# Altium schematic sheet 主控转接板-V2.0.SchDoc(主控转接板-V2.0)
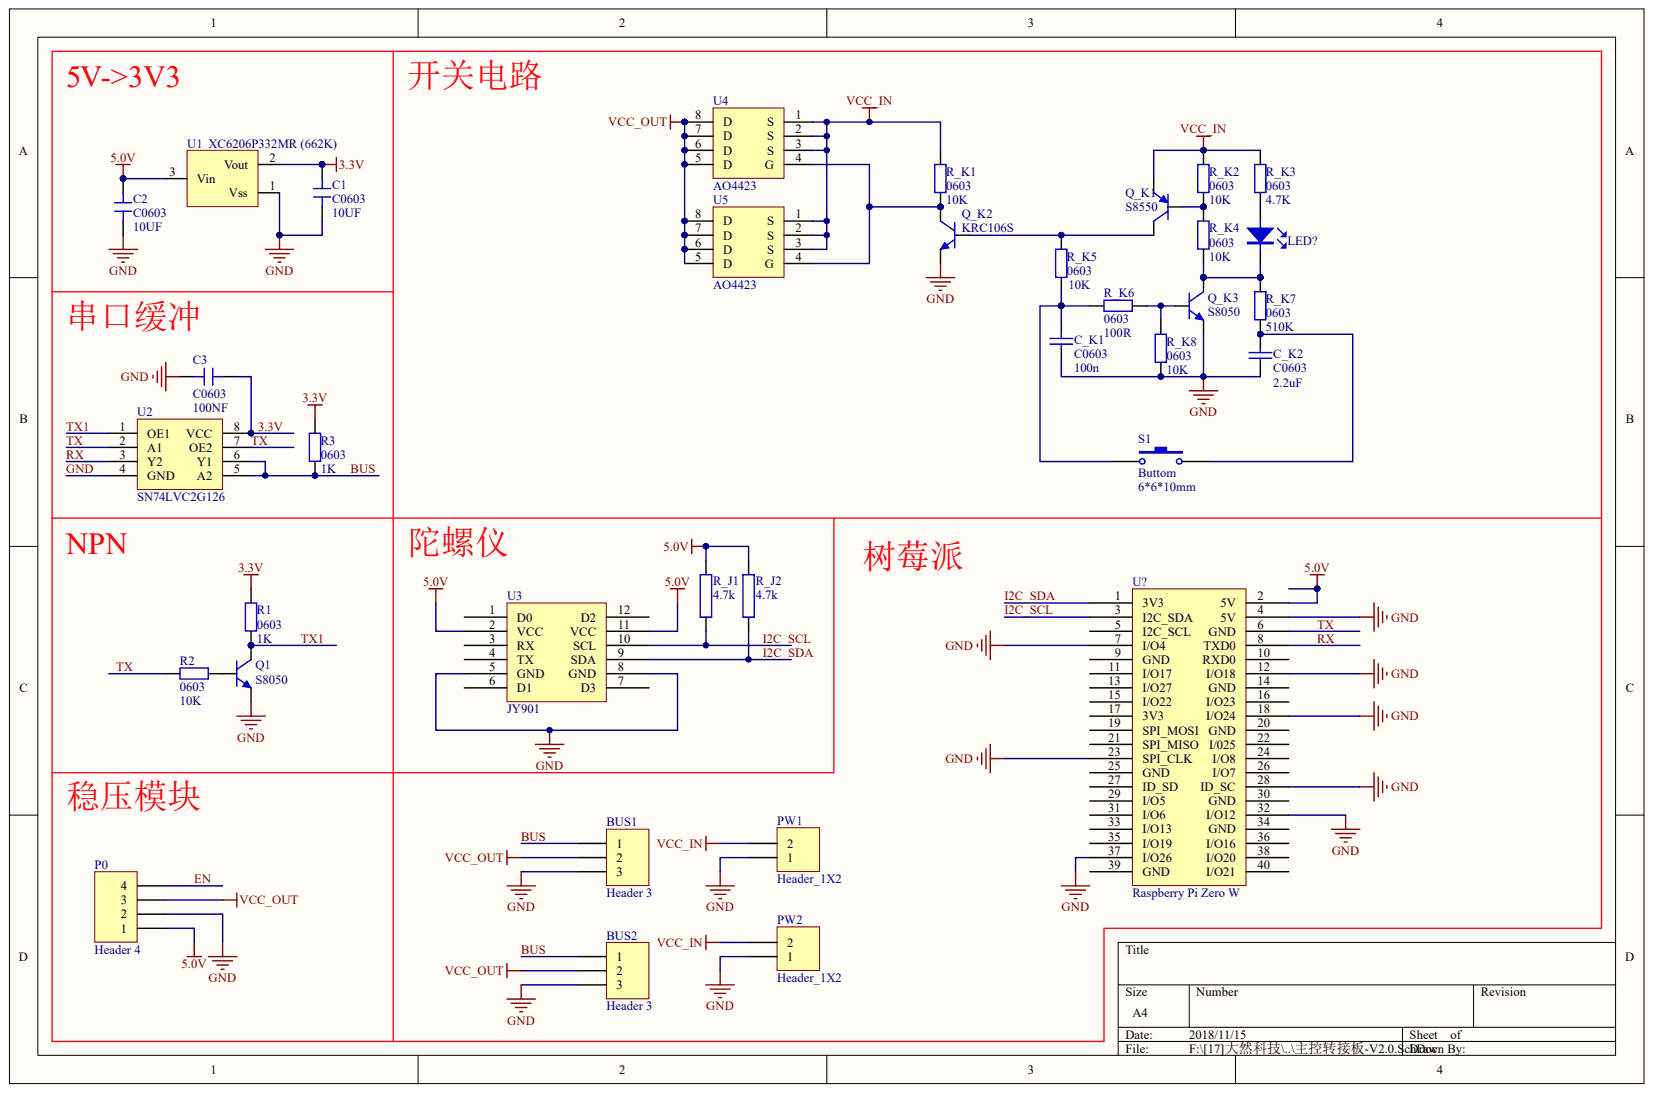
[diagram p0: 主控转接板-V2.0.SchDoc]

## Parts
BUS1  Header 3
BUS2  Header 3
C1  value 10UF  (hidden comment: C0603)
C2  value 10UF  (hidden comment: C0603)
C3  value 100NF  (hidden comment: C0603)
C_K1  value 100n  (hidden comment: C0603)
C_K2  value 2.2uF  (hidden comment: C0603)
LED?  LED
P0  Header 4
PW1  Header_1X2
PW2  Header_1X2
Q1  S8050
Q_K1  S8550
Q_K2  KRC106S
Q_K3  S8050
R1  value 1K  (hidden comment: 0603)
R2  value 10K  (hidden comment: 0603)
R3  value 1K  (hidden comment: 0603)
R_J1  4.7k
R_J2  4.7k
R_K1  value 10K  (hidden comment: 0603)
R_K2  value 10K  (hidden comment: 0603)
R_K3  value 4.7K  (hidden comment: 0603)
R_K4  value 10K  (hidden comment: 0603)
R_K5  value 10K  (hidden comment: 0603)
R_K6  value 100R  (hidden comment: 0603)
R_K7  value 510K  (hidden comment: 0603)
R_K8  value 10K  (hidden comment: 0603)
S1  Buttom  Packaging: 6*6*10mm
U1  XC6206P332MR (662K)
U2  SN74LVC2G126
U3  JY901
U4  AO4423
U5  AO4423
U?  Raspberry Pi Zero W

## Nets
5.0V: C2.2 P0.1 R_J1.1 R_J2.1 U1.3 U3.2(VCC) U3.11(VCC) U?.2(5V)
VCC_IN: PW1.2 PW2.2 Q_K1.2 R_K1.2 R_K2.2 R_K3.2 U4.1(S) U4.2(S) U4.3(S) U5.1(S) U5.2(S) U5.3(S)
VCC_OUT: BUS1.2 BUS2.2 P0.3 U4.5(D) U4.6(D) U4.7(D) U4.8(D) U5.5(D) U5.6(D) U5.7(D) U5.8(D)
3.3V: C1.2 C3.1 R1.2 R3.2 U1.2 U2.8(VCC)
BUS: BUS1.1 BUS2.1 R3.1 U2.5(A2) U2.6(Y1)
EN: P0.4
GND: BUS1.3 BUS2.3 C1.1 C2.1 C3.2 C_K1.1 C_K2.2 P0.2 PW1.1 PW2.1 Q1.2 Q_K2.2 Q_K3.2 R_K8.1 U1.1 U2.4(GND) U3.5(GND) U3.8(GND) U?.4(5V) U?.7(I/O4) U?.12(I/O18) U?.18(I/O24) U?.23(SPI_CLK) U?.28(ID_SC) U?.32(I/O12) U?.37(I/O26)
I2C_SCL: R_J1.2 U3.10(SCL) U?.3(I2C_SDA)
I2C_SDA: R_J2.2 U3.9(SDA) U?.1(3V3)
RX: U2.3(Y2) U?.8(TXD0)
TX: R2.2 U2.2(A1) U2.7(OE2) U?.6(GND)
TX1: Q1.3 R1.1 U2.1(OE1)
NetC_K1_2: C_K1.2 R_K5.1 R_K6.2 S1.1
NetC_K2_1: C_K2.1 R_K7.1 S1.2
NetLED?_A: LED?.A R_K3.1
NetLED?_K: LED?.K Q_K3.3 R_K4.1 R_K7.2
NetQ1_1: Q1.1 R2.1
NetQ_K1_1: Q_K1.1 R_K2.1 R_K4.2
NetQ_K1_3: Q_K1.3 Q_K2.1 R_K5.2
NetQ_K2_3: Q_K2.3 R_K1.1 U4.4(G) U5.4(G)
NetQ_K3_1: Q_K3.1 R_K6.1 R_K8.2

Not connected: U3 1(D0) 3(RX) 4(TX) 6(D1) 7(D3) 12(D2); U? 5(I2C_SCL) 9(GND) 10(RXD0) 11(I/O17) 13(I/O27) 14(GND) 15(I/O22) 16(I/O23) 17(3V3) 19(SPI_MOSI) 20(GND) 21(SPI_MISO) 22(I/025) 24(I/O8) 25(GND) 26(I/O7) 27(ID_SD) 29(I/O5) 30(GND) 31(I/O6) 33(I/O13) 34(GND) 35(I/O19) 36(I/O16) 38(I/O20) 39(GND) 40(I/O21)

## Library data of the parts
C1 C2 C_K1 C_K2 = (no symbol name): Pressure resistance: 16V; Accuracy: 5%; Packaging: C0603
C3 = (no symbol name): Pressure resistance: 16V; Accuracy: 5%; value: 100NF; Packaging: C0603
R1-R3 R_K1-R_K5 R_K7 R_K8 = (no symbol name): Accuracy: 1%; Packaging: 0603
R_K6 = (no symbol name): Accuracy: 1%; Value: 100R; Packaging: 0603
U4 U5 = (no symbol name): value: P-MOS; Packaging: *
BUS1 BUS2 LED? P0 PW1 PW2 Q1 Q_K1-Q_K3 R_J1 R_J2 S1 U1-U3 U? = (no symbol name)
  BUS1 BUS2 P0: LatestRevisionDate: 17-Jul-2002; LatestRevisionNote: Re-released for DXP Platform.
  Q1 Q_K2 Q_K3: Published: 8-Jun-2000; LatestRevisionDate: 17-Jul-2002; LatestRevisionNote: Re-released for DXP Platform.; PackageReference: TO-226-AA; PackageDescription: TO, Flat Index; 3 In-Line, Axial Leads; Body Dia. 4.8mm; Leads 0.55 x 0.51 mm (max); Code_JEDEC: TO-226-AA; Packaging: *; value: *
  Q_K1: Published: 8-Jun-2000; LatestRevisionDate: 29-May-2009; LatestRevisionNote: IPC-7351 Footprint Added.; PackageVersion: Aug-1999; PackageReference: SOT-23B; PackageDescription: 3-Pin SOT-23 Package 0.95 mm Pitch, 2.4 mm Lead Span; Packaging: *; value: *
  S1: Published: 24-Mar-1999; LatestRevisionDate: 17-Jul-2002; LatestRevisionNote: Re-released for DXP Platform.; PackageReference: SPST-2; PackageDescription: Switch; 2 Leads
  U1 U2: Packaging: *
  BUS1 BUS2 P0 Q1 Q_K1-Q_K3 S1: Publisher: Altium Limited
